# Altium schematic sheet Power&Com.SchDoc
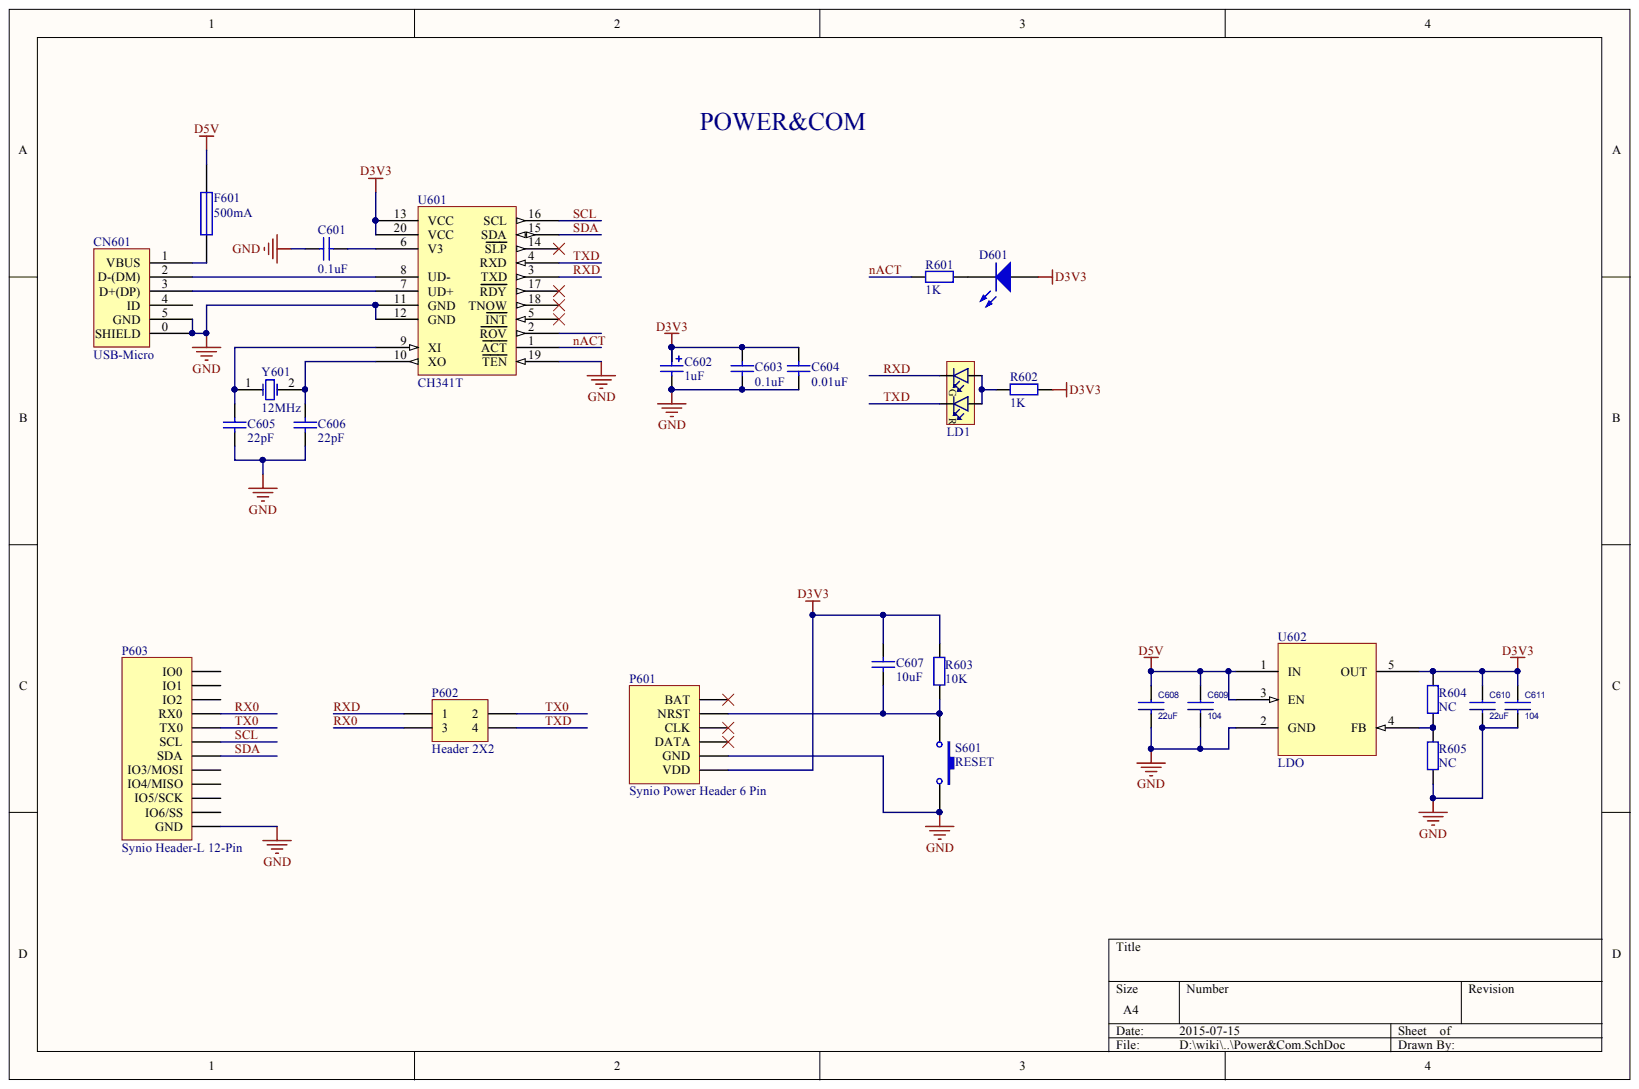
[diagram p0: Power&Com.SchDoc]

## Parts
C601  0.1uF  [C0603L]
C602  1uF  [0805M]
C603  value 0.1uF  [C0603L]  (hidden comment: Cap)
C604  value 0.01uF  [C0603L]  (hidden comment: Cap)
C605  value 22pF  [C0603L]  (hidden comment: Cap)
C606  value 22pF  [C0603L]  (hidden comment: Cap)
C607  10uF  [C0603L]
C608  22uF  [C0805]
C609  104  [C0603L]
C610  22uF  [C0805]
C611  104  [C0603L]
CN601  USB-Micro  [USB-MICRO-Lite]
D601  LED1  [0603pn]  (value not drawn)
F601  500mA  [0805]
LD1  LTST-C195KGJRKT  [LED_DUAL_0606]  (value not drawn)
P601  Synio Power Header 6 Pin  [HDR1X6/2.54MM]
P602  Header 2X2  [HDR2X2/2.54MM]
P603  Synio Header-L 12-Pin  [HDR1X12]
R601  1K  [R0603]
R602  1K  [0603M]
R603  10K  [0603M]
R604  NC  [0603M]
R605  NC  [0603M]
S601  RESET  [KEY-2x4-SMD]
U601  CH341T  [SSOP20]
U602  LDO  [SOT23-5]
Y601  12MHz  [XTAL0803]

## Nets
D3V3: C602.1 C603.2 C604.2 C607.2 C610.2 C611.2 D601.1 P601.1(VDD) R602.1 R603.1 R604.1 U601.13(VCC) U601.20(VCC) U602.5(OUT)
D5V: C608.2 C609.2 F601.1 U602.1(IN) U602.3(EN)
GND: C601.1 C602.2 C603.1 C604.1 C605.1 C606.1 C608.1 C609.1 C610.1 C611.1 CN601.0(SHIELD) CN601.5(GND) P601.2(GND) P603.1(GND) R605.1 S601.1 U601.11(GND) U601.12(GND) U601.19(TEN) U602.2(GND)
NACT: R601.1 U601.1(ACT)
RX0: P602.3 P603.9(RX0)
RXD: LD1.1 P602.1 U601.3(TXD)
SCL: P603.7(SCL) U601.16(SCL)
SDA: P603.6(SDA) U601.15(SDA)
TX0: P602.2 P603.8(TX0)
TXD: LD1.2 P602.4 U601.4(RXD)
NetC601_2: C601.2 U601.6(V3)
NetC605_2: C605.2 U601.9(XI) Y601.1
NetC606_2: C606.2 U601.10(XO) Y601.2
NetC607_1: C607.1 P601.5(NRST) R603.2 S601.2
NetCN601_1: CN601.1(VBUS) F601.2
NetCN601_2: CN601.2(D-(DM)) U601.8(UD-)
NetCN601_3: CN601.3(D+(DP)) U601.7(UD+)
NetD601_2: D601.2 R601.2
NetLD1_3: LD1.3 LD1.4 R602.2
NetR604_2: R604.2 R605.2 U602.4(FB)

Not connected: CN601 4(ID); P601 3(DATA) 4(CLK) 6(BAT); P603 2(IO6/SS) 3(IO5/SCK) 4(IO4/MISO) 5(IO3/MOSI) 10(IO2) 11(IO1) 12(IO0); U601 2(ROV) 5(INT) 14(SLP) 17(RDY) 18(TNOW)

## Library data of the parts
C601 C603-C607 = Cap: Capacitor; Component Kind: Standard; LatestRevisionDate: 17-Jul-2002; LatestRevisionNote: Re-released for DXP Platform.; PackageReference: RAD-0.3; Published: 8-Jun-2000; Signal Integrity: Cap; Simulation: CAP
  C601: Library Name: Studio.SchLib; Value: 0.1uF
  C603-C606: Library Name: Miscellaneous Devices.IntLib
  C607: Library Name: Miscellaneous Devices.IntLib; Value: 10uF
  C603-C607: Publisher: Altium Limited
C602 = Cap Pol1: Polarized Capacitor (Radial); Component Kind: Standard; LatestRevisionDate: 17-Jul-2002; LatestRevisionNote: Re-released for DXP Platform.; Library Name: Studio.SchLib; PackageReference: RB7.6-15; Published: 8-Jun-2000; Signal Integrity: Cap Pol; Simulation: CAP; Value: 1uF; Publisher: Altium Limited
C608-C611 = CAP: : 符观辉; Component Kind: Standard; Library Name: Mylib.SchLib; PCB3D: C0402
D601 = LED1: Typical RED GaAs LED; Component Kind: Standard; LatestRevisionDate: 08-Jul-2005; LatestRevisionNote: Mech Layer 1 removed.; Library Name: Studio.SchLib; PackageReference: LED-1; Published: 8-Jun-2000; Simulation: LED1; Publisher: Altium Limited
F601 = Fuse 1: Fuse; Component Kind: Standard; LatestRevisionDate: 17-Jul-2002; LatestRevisionNote: Re-released for DXP Platform.; Library Name: Studio.SchLib; PackageDescription: Thru-Hole; 2 Leads; PackageReference: PIN-W2/E2.8; Published: 8-Jun-2000; Simulation: FUSE; Publisher: Altium Limited
LD1 = (no symbol name): Avago, HSMF-A203 Bi-Color LED; 2nd source part number: 859-LTST-C195KGJRKT; Manufacturer: Lite-On Inc; Artikkelnr Noca: 13019145; Note: HSMF-A203- xxxxx GaP Red GaP Emerald Green; 2nd source Supplier: Mouser; Component Kind: Standard; Datasheet: http://media.digikey.com/pdf/Data%20Sheets/Lite-On%20PDFs/LTST-C195KGJRKT.pdf; LatestRevisionDate: 2012-07-05; Library Name: Misc.SchLib; Manufacturer Part Number: LTST-C195KGJRKT; Part Number: LTST-C195KGJRKT; Pin Count: 4; Published: 2012-07-05; Supplier: Digi-Key; Supplier part number: 160-1452-1-ND; Value: LTST-C195KGJRKT; Publisher: Nordic Semiconductor
P602 = Header 2X2: Header, 2-Pin, Dual row; Component Kind: Standard; LatestRevisionDate: 17-Jul-2002; LatestRevisionNote: Re-released for DXP Platform.; Library Name: Miscellaneous Connectors.IntLib; Signal Integrity: Connector; Publisher: Altium Limited
P603 = Synio Header-L 12-Pin: Synio Header-L, 12-Pin; Component Kind: Standard; LatestRevisionDate: 17-Jul-2002; LatestRevisionNote: Re-released for DXP Platform.; Library Name: Connector.SchLib; Signal Integrity: Connector; Publisher: Altium Limited
R601 = Res: Resistor; Component Kind: Standard; LatestRevisionDate: 17-Jul-2002; LatestRevisionNote: Re-released for DXP Platform.; Library Name: Studio.SchLib; PackageReference: AXIAL-0.4; Published: 8-Jun-2000; Signal Integrity: Res; Simulation: RESISTOR; Value: 1K; Publisher: Altium Limited
R602-R605 = Res2: Resistor; Component Kind: Standard; LatestRevisionDate: 17-Jul-2002; LatestRevisionNote: Re-released for DXP Platform.; Library Name: Miscellaneous Devices.IntLib; PackageReference: AXIAL-0.4; Published: 8-Jun-2000; Signal Integrity: Res; Simulation: RESISTOR; Publisher: Altium Limited
  R602: Value: 1K
  R603: Value: 10K
  R604 R605: Value: NC
S601 = SW-PB: Switch; Component Kind: Standard; LatestRevisionDate: 17-Jul-2002; LatestRevisionNote: Re-released for DXP Platform.; Library Name: Miscellaneous Devices.IntLib; PackageReference: SPST-2; Published: 24-Mar-1999; Publisher: Altium Limited
U602 = LDO-SOT23-5: General LDO SOT23-5; Component Kind: Standard; Library Name: Power.SchLib
Y601 = XTAL: Crystal Oscillator; Component Kind: Standard; LatestRevisionDate: 17-Jul-2002; LatestRevisionNote: Re-released for DXP Platform.; Library Name: Miscellaneous Devices.IntLib; PackageReference: R38; Published: 8-Jun-2000; Simulation: XTAL; Publisher: Altium Limited
CN601 = USB-Micro: Component Kind: Standard; Description: USB-Micro; Library Name: Connector.SchLib
P601 = Synio Power Header 6 Pin: Component Kind: Standard; Description: Synio Power Header 6 Pin; Library Name: Connector.SchLib
U601 = CH341T: Component Kind: Standard; Description: CH341T; Library Name: Studio.SchLib
